# Altium schematic sheet 土壤传感器V1.SchDoc(土壤传感器V1)
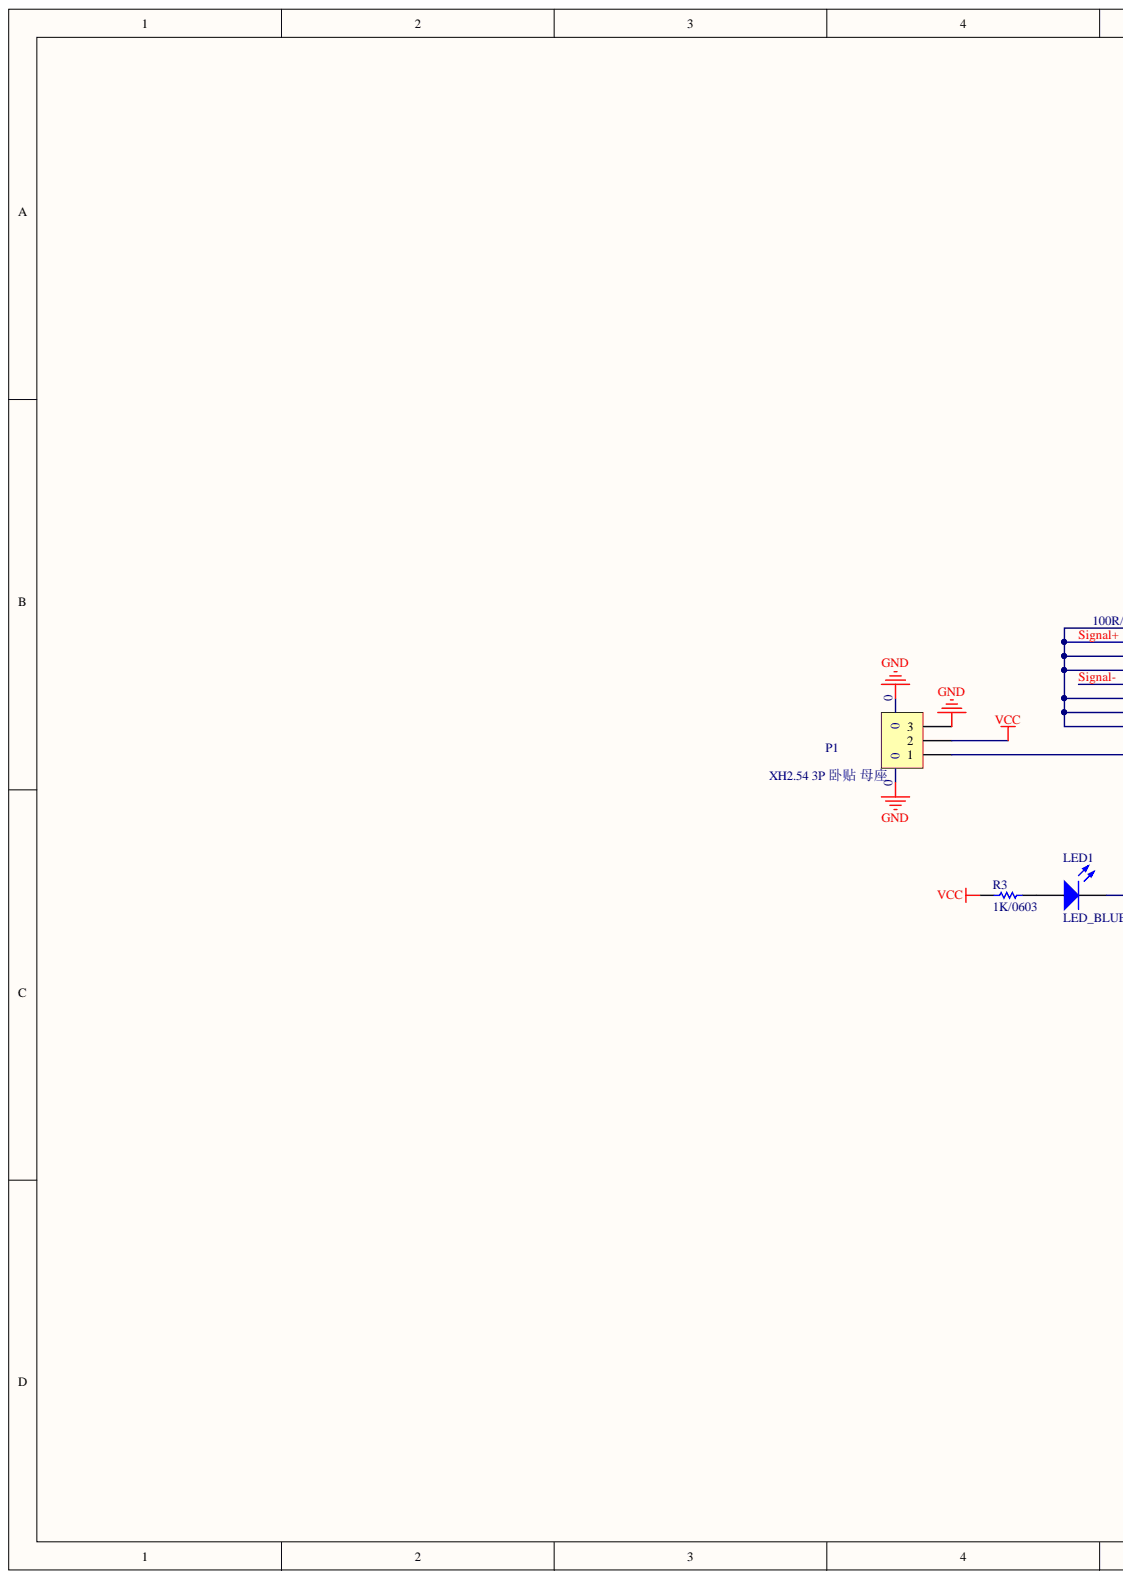
[diagram p0: 土壤传感器V1.SchDoc, left]
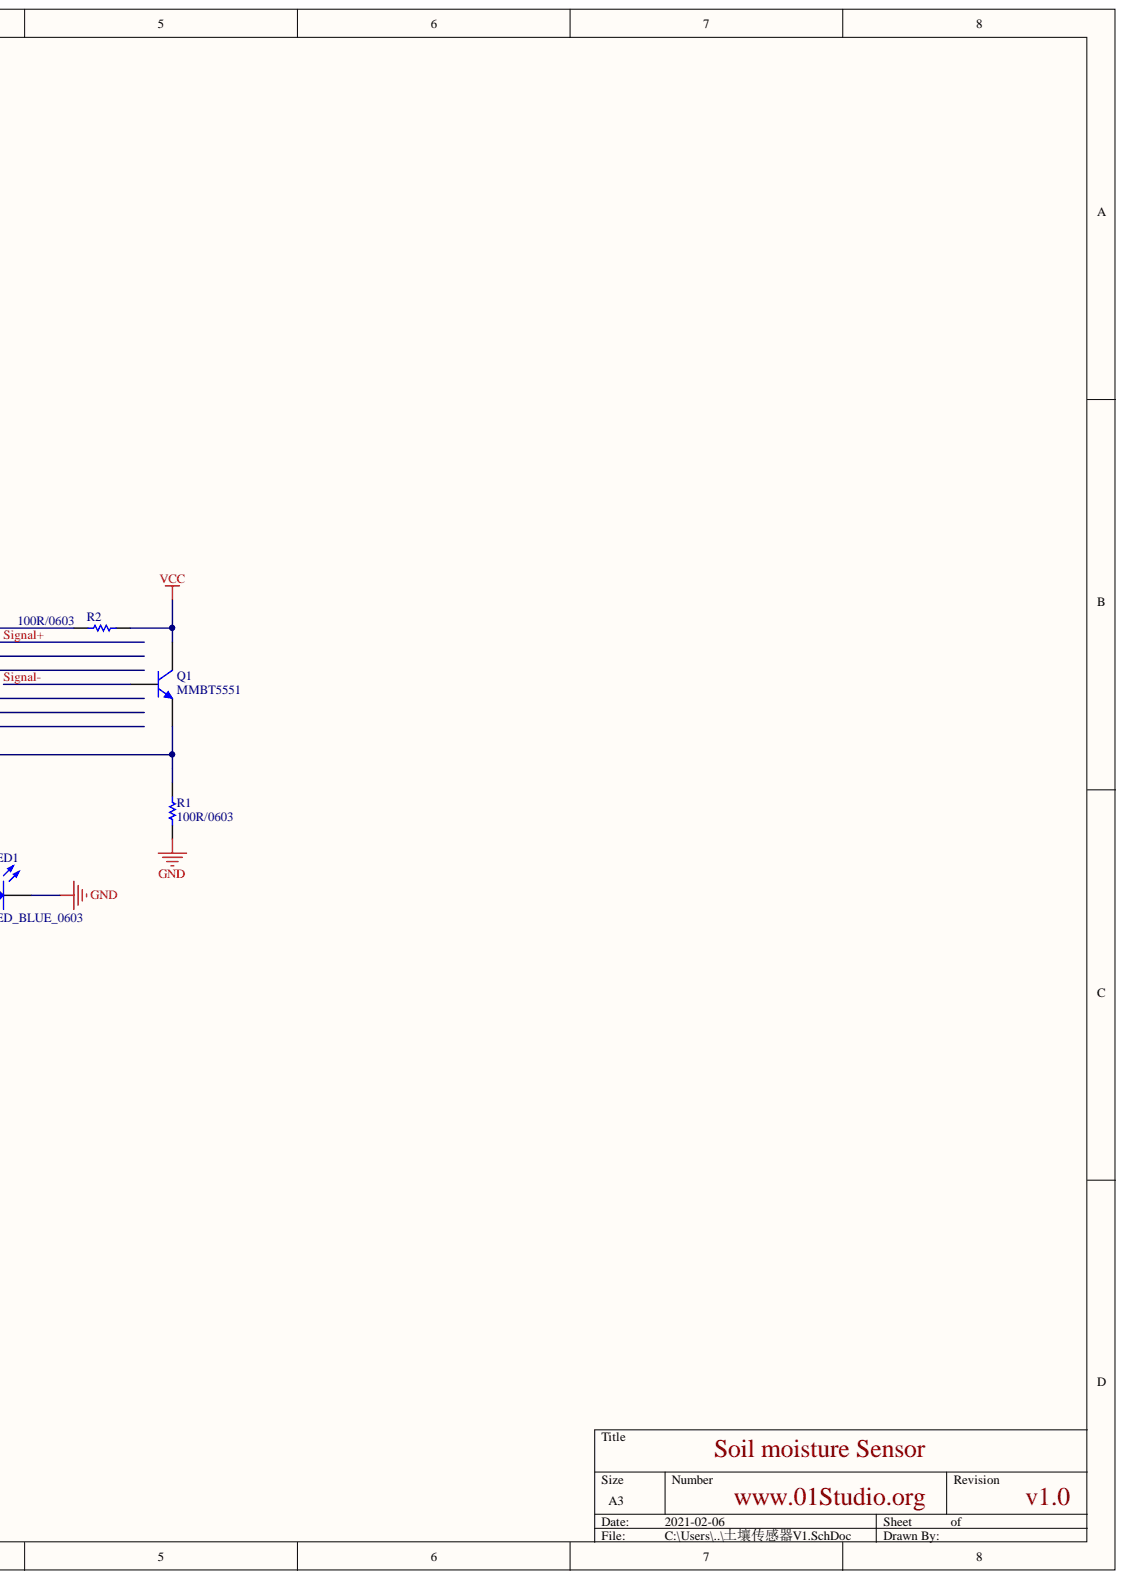
[diagram p1: 土壤传感器V1.SchDoc, right]

## Parts
LED1  LED_BLUE_0603  [LED0603]
P1  XH2.54 3P 卧贴 母座  [XH2.54mm 3P]
Q1  MMBT5551  [SOT-23]
R1  100R/0603  [R0603]
R2  100R/0603  [R0603]
R3  1K/0603  [R0603]

## Nets
GND: LED1.2 P1.0 P1.3 R1.2
VCC: P1.2 Q1.3 R2.2 R3.1
Signal+: R2.1
Signal-: Q1.1
NetLED1_1: LED1.1 R3.2
NetP1_1: P1.1 Q1.2 R1.1

## Library data of the parts
LED1 = LED0: Typical INFRARED GaAs LED; Simulation: LED0; Published: 8-Jun-2000; PackageReference: LED-0; Library Name: Miscellaneous Devices.IntLib; LatestRevisionNote: Mech Layer 1 removed.; LatestRevisionDate: 08-Jul-2005; Component Kind: Standard; Publisher: Altium Limited
P1 = Header 3: Header, 3-Pin; Signal Integrity: Connector; LatestRevisionNote: Re-released for DXP Platform.; LatestRevisionDate: 17-Jul-2002; Component Kind: Standard; Publisher: Altium Limited; Library Name: MicroBit-EVK V1.SchLib
Q1 = S8050: Library Name: We_CC2540.SCHLIB; Component Kind: Standard
R1-R3 = Res1: Resistor; Published: 8-Jun-2000; PackageReference: AXIAL-0.3; LatestRevisionNote: Re-released for DXP Platform.; LatestRevisionDate: 17-Jul-2002; Component Kind: Standard; Publisher: Altium Limited
  R3: Simulation: RESISTOR; Signal Integrity: Res; Library Name: Miscellaneous Devices.IntLib
